# Altium schematic sheet BREEZE Pro ESC-D V1.SchDoc
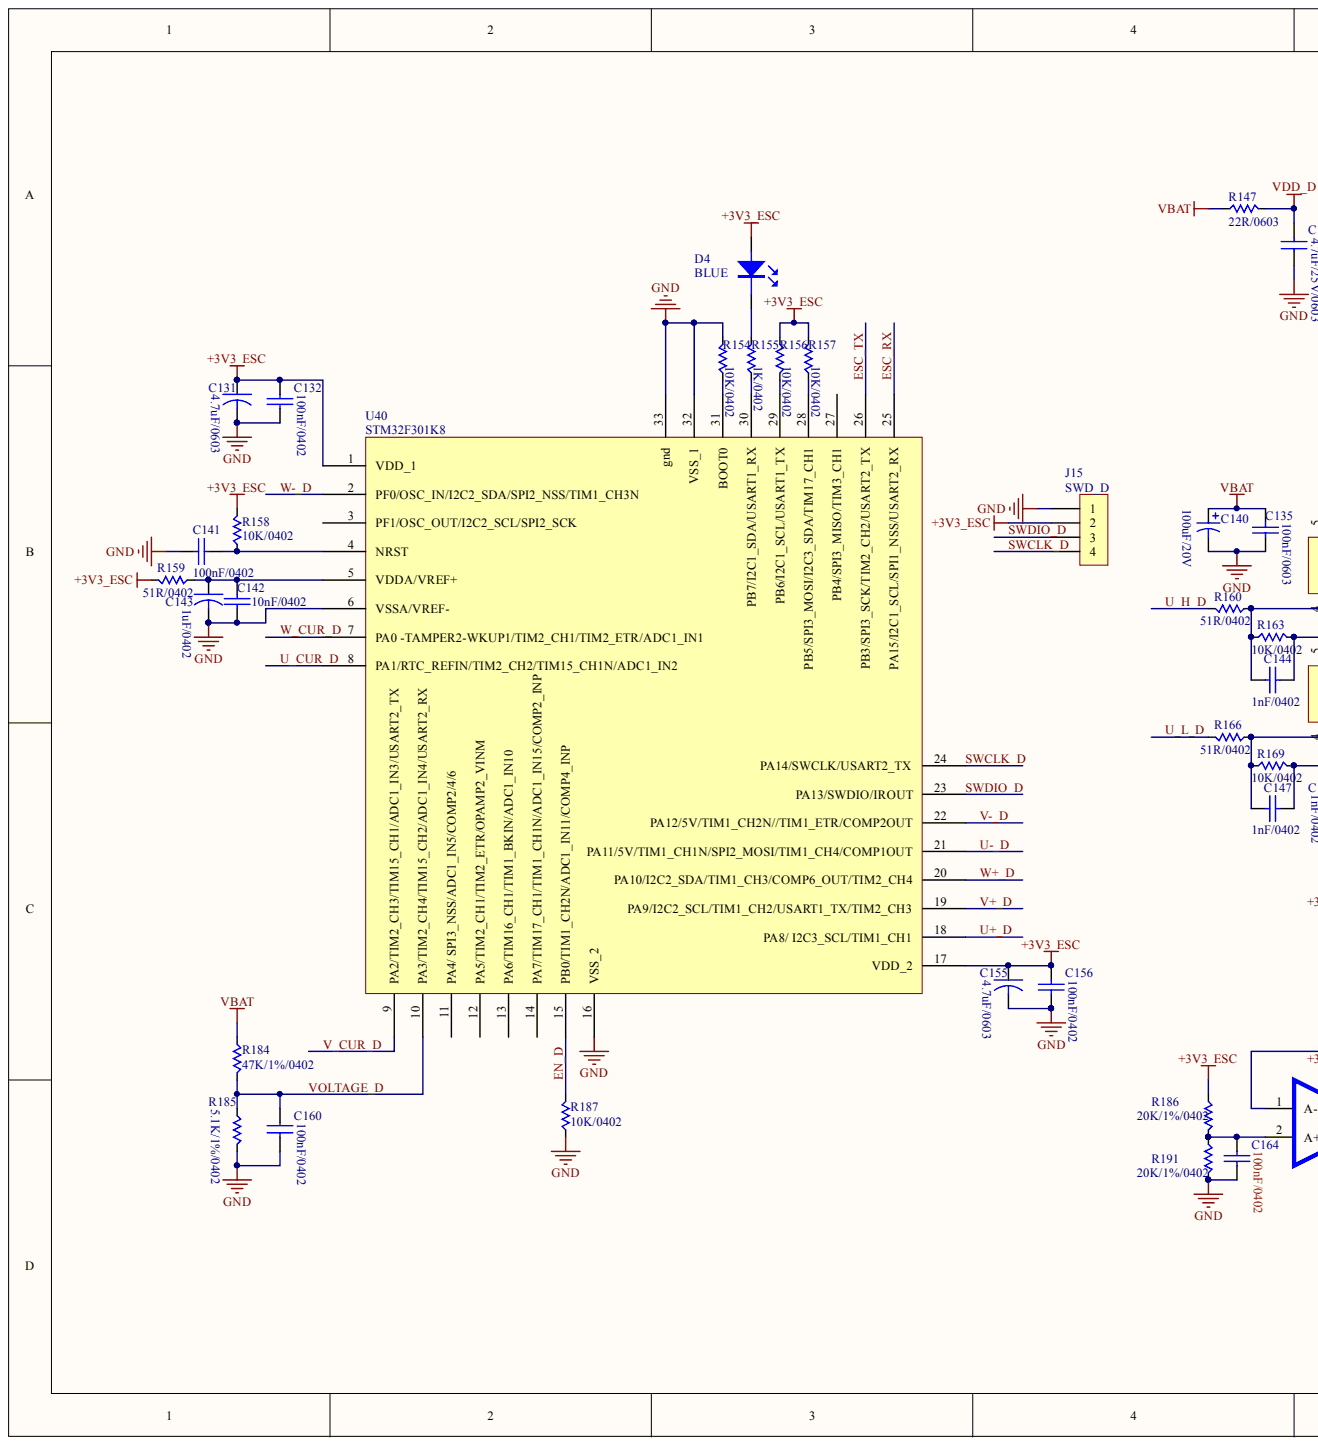
[diagram p0: BREEZE Pro ESC-D V1.SchDoc, left]
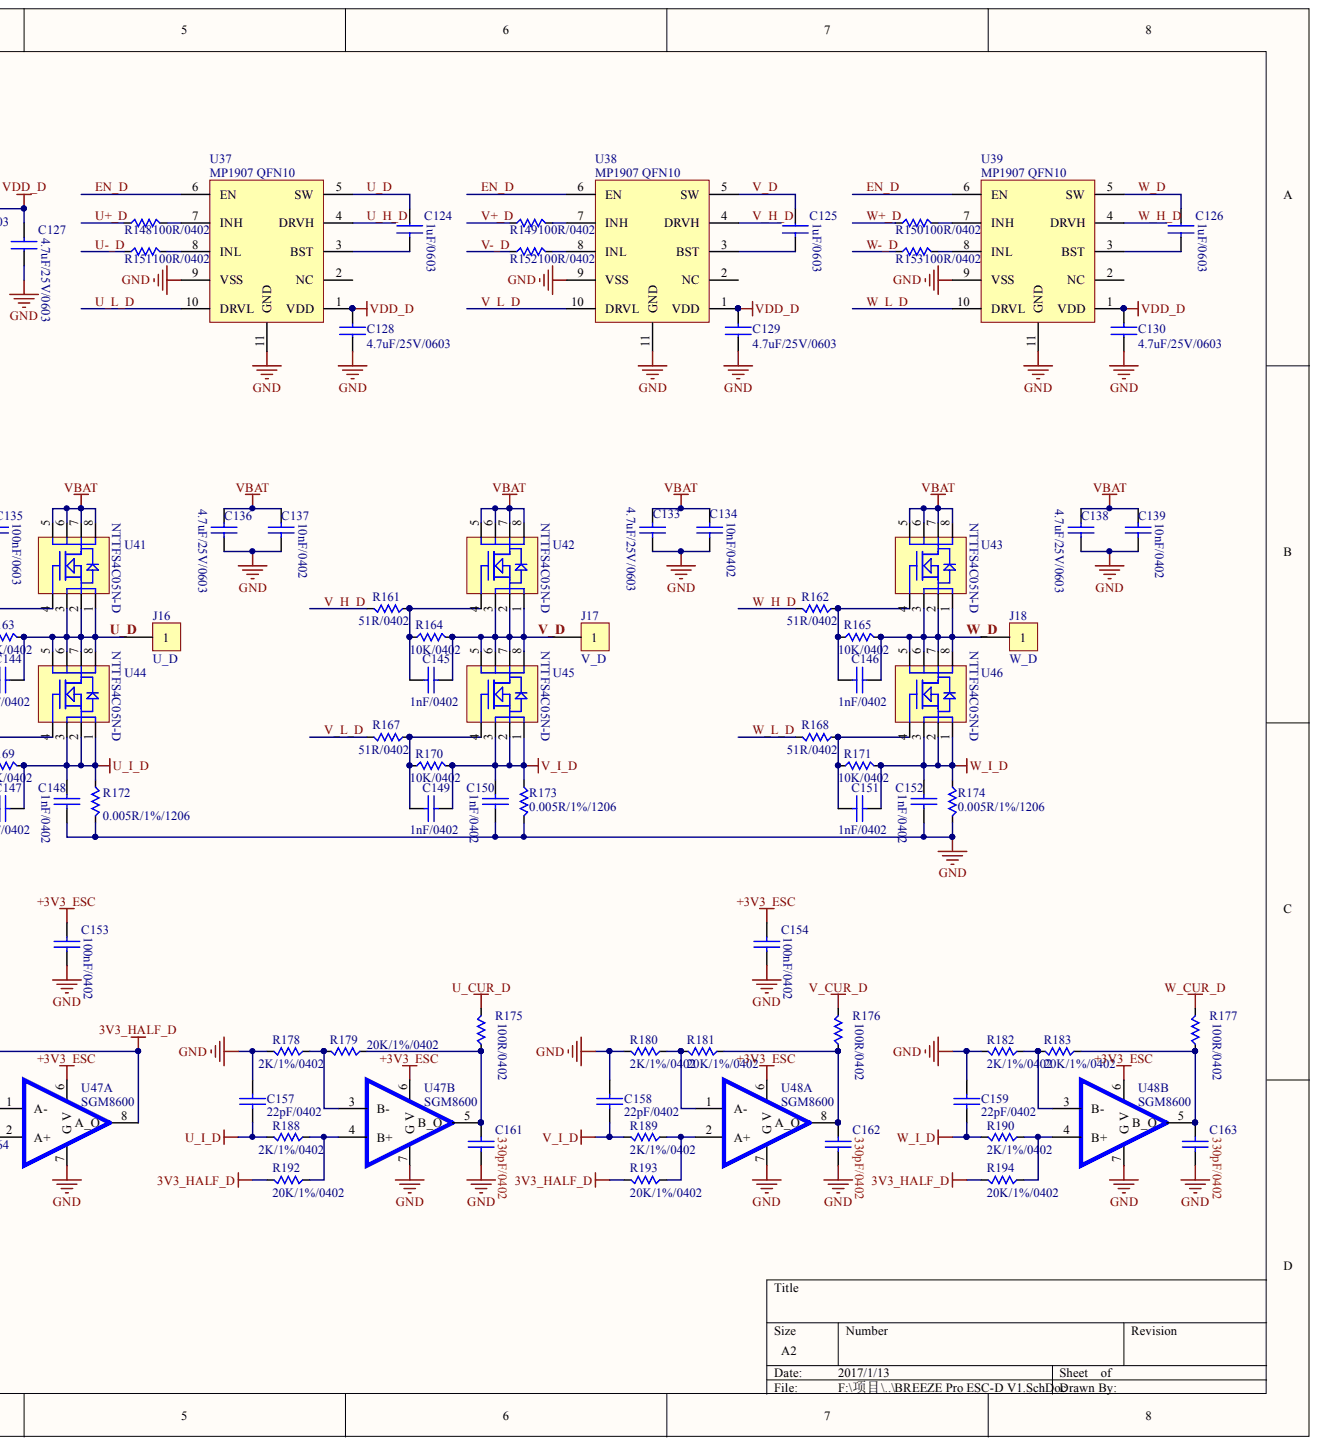
[diagram p1: BREEZE Pro ESC-D V1.SchDoc, right]

## Parts
C124  1uF/0603
C125  1uF/0603
C126  1uF/0603
C127  4.7uF/25V/0603
C128  4.7uF/25V/0603
C129  4.7uF/25V/0603
C130  4.7uF/25V/0603
C131  4.7uF/0603
C132  100nF/0402
C133  4.7uF/25V/0603
C134  10nF/0402
C135  100nF/0603
C136  4.7uF/25V/0603
C137  10nF/0402
C138  4.7uF/25V/0603
C139  10nF/0402
C140  100uF/20V
C141  100nF/0402
C142  10nF/0402
C143  1uF/0402
C144  1nF/0402
C145  1nF/0402
C146  1nF/0402
C147  1nF/0402
C148  1nF/0402
C149  1nF/0402
C150  1nF/0402
C151  1nF/0402
C152  1nF/0402
C153  100nF/0402
C154  100nF/0402
C155  4.7uF/0603
C156  100nF/0402
C157  22pF/0402
C158  22pF/0402
C159  22pF/0402
C160  100nF/0402
C161  330pF/0402
C162  330pF/0402
C163  330pF/0402
C164  100nF/0402
D4  BLUE
J15  SWD_D
J16  U_D
J17  V_D
J18  W_D
R147  22R/0603
R148  100R/0402
R149  100R/0402
R150  100R/0402
R151  100R/0402
R152  100R/0402
R153  100R/0402
R154  10K/0402
R155  1K/0402
R156  10K/0402
R157  10K/0402
R158  10K/0402
R159  51R/0402
R160  51R/0402
R161  51R/0402
R162  51R/0402
R163  10K/0402
R164  10K/0402
R165  10K/0402
R166  51R/0402
R167  51R/0402
R168  51R/0402
R169  10K/0402
R170  10K/0402
R171  10K/0402
R172  0.005R/1%/1206
R173  0.005R/1%/1206
R174  0.005R/1%/1206
R175  100R/0402
R176  100R/0402
R177  100R/0402
R178  2K/1%/0402
R179  20K/1%/0402
R180  2K/1%/0402
R181  20K/1%/0402
R182  2K/1%/0402
R183  20K/1%/0402
R184  47K/1%/0402
R185  5.1K/1%/0402
R186  20K/1%/0402
R187  10K/0402
R188  2K/1%/0402
R189  2K/1%/0402
R190  2K/1%/0402
R191  20K/1%/0402
R192  20K/1%/0402
R193  20K/1%/0402
R194  20K/1%/0402
U37  MP1907 QFN10
U38  MP1907 QFN10
U39  MP1907 QFN10
U40  STM32F301K8
U41  NTTFS4C05N-D
U42  NTTFS4C05N-D
U43  NTTFS4C05N-D
U44  NTTFS4C05N-D
U45  NTTFS4C05N-D
U46  NTTFS4C05N-D
U47  SGM8600  (parts A,B)
U48  SGM8600  (parts A,B)

## Nets
3V3_HALF_D: R192.2 R193.2 R194.2 U47.1(A-) U47.8(A_O)
+3V3_ESC: C131.1 C132.1 C153.1 C154.1 C155.1 C156.1 D4.1 J15.2 R156.1 R157.2 R158.2 R159.2 R186.1 U40.1(VDD_1) U40.17(VDD_2) U47.6(V) U48.6(V)
GND: C127.1 C128.1 C129.1 C130.1 C131.2 C132.2 C133.2 C134.2 C135.2 C136.2 C137.2 C138.2 C139.2 C140.2 C141.2 C142.2 C143.2 C148.2 C150.2 C152.2 C153.2 C154.2 C155.2 C156.2 C157.1 C158.1 C159.1 C160.2 C161.1 C162.1 C163.1 C164.1 J15.1 R154.2 R172.2 R173.2 R174.2 R178.2 R180.2 R182.2 R185.1 R187.1 R191.2 U37.9(VSS) U37.11(GND) U38.9(VSS) U38.11(GND) U39.9(VSS) U39.11(GND) U40.6(VSSA/VREF-) U40.16(VSS_2) U40.32(VSS_1) U40.33(gnd) U47.7(G) U48.7(G)
U_I_D: C147.2 C148.1 C157.2 R169.2 R172.1 R188.2 U44.1 U44.2 U44.3
V_I_D: C149.2 C150.1 C158.2 R170.2 R173.1 R189.2 U45.1 U45.2 U45.3
VBAT: C133.1 C134.1 C135.1 C136.1 C137.1 C138.1 C139.1 C140.1 R147.1 R184.2 U41.5 U41.6 U41.7 U41.8 U42.5 U42.6 U42.7 U42.8 U43.5 U43.6 U43.7 U43.8
VDD_D: C127.2 C128.2 C129.2 C130.2 R147.2 U37.1(VDD) U38.1(VDD) U39.1(VDD)
W_I_D: C151.2 C152.1 C159.2 R171.2 R174.1 R190.2 U46.1 U46.2 U46.3
EN_D: R187.2 U37.6(EN) U38.6(EN) U39.6(EN) U40.15(PB0/TIM1_CH2N/ADC1_IN11/COMP4_INP)
ESC_RX: U40.25(PA15/I2C1_SCL/SPI1_NSS/USART2_RX)
ESC_TX: U40.26(PB3/SPI3_SCK/TIM2_CH2/USART2_TX)
SWCLK_D: J15.4 U40.24(PA14/SWCLK/USART2_TX)
SWDIO_D: J15.3 U40.23(PA13/SWDIO/IROUT)
U+_D: R148.1 U40.18(PA8/_I2C3_SCL/TIM1_CH1)
U-_D: R151.1 U40.21(PA11/5V/TIM1_CH1N/SPI2_MOSI/TIM1_CH4/COMP1OUT)
U_CUR_D: R175.2 U40.8(PA1/RTC_REFIN/TIM2_CH2/TIM15_CH1N/ADC1_IN2)
U_D: C124.1 C144.2 J16.1 R163.2 U37.5(SW) U41.1 U41.2 U41.3 U44.5 U44.6 U44.7 U44.8
U_H_D: R160.2 U37.4(DRVH)
U_L_D: R166.2 U37.10(DRVL)
V+_D: R149.1 U40.19(PA9/I2C2_SCL/TIM1_CH2/USART1_TX/TIM2_CH3)
V-_D: R152.1 U40.22(PA12/5V/TIM1_CH2N//TIM1_ETR/COMP2OUT)
V_CUR_D: R176.2 U40.9(PA2/TIM2_CH3/TIM15_CH1/ADC1_IN3/USART2_TX)
V_D: C125.1 C145.2 J17.1 R164.2 U38.5(SW) U42.1 U42.2 U42.3 U45.5 U45.6 U45.7 U45.8
V_H_D: R161.2 U38.4(DRVH)
V_L_D: R167.2 U38.10(DRVL)
VOLTAGE_D: C160.1 R184.1 R185.2 U40.10(PA3/TIM2_CH4/TIM15_CH2/ADC1_IN4/USART2_RX)
W+_D: R150.1 U40.20(PA10/I2C2_SDA/TIM1_CH3/COMP6_OUT/TIM2_CH4)
W-_D: R153.1 U40.2(PF0/OSC_IN/I2C2_SDA/SPI2_NSS/TIM1_CH3N)
W_CUR_D: R177.2 U40.7(PA0_-TAMPER2-WKUP1/TIM2_CH1/TIM2_ETR/ADC1_IN1)
W_D: C126.1 C146.2 J18.1 R165.2 U39.5(SW) U43.1 U43.2 U43.3 U46.5 U46.6 U46.7 U46.8
W_H_D: R162.2 U39.4(DRVH)
W_L_D: R168.2 U39.10(DRVL)
NetC124_2: C124.2 U37.3(BST)
NetC125_2: C125.2 U38.3(BST)
NetC126_2: C126.2 U39.3(BST)
NetC141_1: C141.1 R158.1 U40.4(NRST)
NetC142_1: C142.1 C143.1 R159.1 U40.5(VDDA/VREF+)
NetC144_1: C144.1 R160.1 R163.1 U41.4
NetC145_1: C145.1 R161.1 R164.1 U42.4
NetC146_1: C146.1 R162.1 R165.1 U43.4
NetC147_1: C147.1 R166.1 R169.1 U44.4
NetC149_1: C149.1 R167.1 R170.1 U45.4
NetC151_1: C151.1 R168.1 R171.1 U46.4
NetC161_2: C161.2 R175.1 R179.1 U47.5(B_O)
NetC162_2: C162.2 R176.1 R181.1 U48.8(A_O)
NetC163_2: C163.2 R177.1 R183.1 U48.5(B_O)
NetC164_2: C164.2 R186.2 R191.1 U47.2(A+)
NetD4_2: D4.2 R155.1
NetR148_2: R148.2 U37.7(INH)
NetR149_2: R149.2 U38.7(INH)
NetR150_2: R150.2 U39.7(INH)
NetR151_2: R151.2 U37.8(INL)
NetR152_2: R152.2 U38.8(INL)
NetR153_2: R153.2 U39.8(INL)
NetR154_1: R154.1 U40.31(BOOT0)
NetR155_2: R155.2 U40.30(PB7/I2C1_SDA/USART1_RX)
NetR156_2: R156.2 U40.29(PB6/I2C1_SCL/USART1_TX)
NetR157_1: R157.1 U40.28(PB5/SPI3_MOSI/I2C3_SDA/TIM17_CH1)
NetR178_1: R178.1 R179.2 U47.3(22pF/0402)
NetR180_1: R180.1 R181.2 U48.1(A-)
NetR182_1: R182.1 R183.2 U48.3(22pF/0402)
NetR188_1: R188.1 R192.1 U47.4
NetR189_1: R189.1 R193.1 U48.2(A+)
NetR190_1: R190.1 R194.1 U48.4

Not connected: U37 2(NC); U38 2(NC); U39 2(NC); U40 3(PF1/OSC_OUT/I2C2_SCL/SPI2_SCK) 11(PA4/_SPI3_NSS/ADC1_IN5/COMP2/4/6) 12(PA5/TIM2_CH1/TIM2_ETR/OPAMP2_VINM) 13(PA6/TIM16_CH1/TIM1_BKIN/ADC1_IN10) 14(PA7/TIM17_CH1/TIM1_CH1N/ADC1_IN15/COMP2_INP) 27(PB4/SPI3_MISO/TIM3_CH1)

## Library data of the parts
C124-C130 C160 = (no symbol name): Text Field1: 20; Text Field2: 10
C131-C159 C161-C164 D4 J15-J18 R147-R194 U37-U48 = (no symbol name)
  C140: Published: 8-Jun-2000; LatestRevisionDate: 17-Jul-2002; LatestRevisionNote: Re-released for DXP Platform.; PackageReference: RB7.6-15; Publisher: Altium Limited
